# Altium schematic sheet DigitalLabBoard_Stepper.SchDoc(DigitalLabBoard_Stepper)
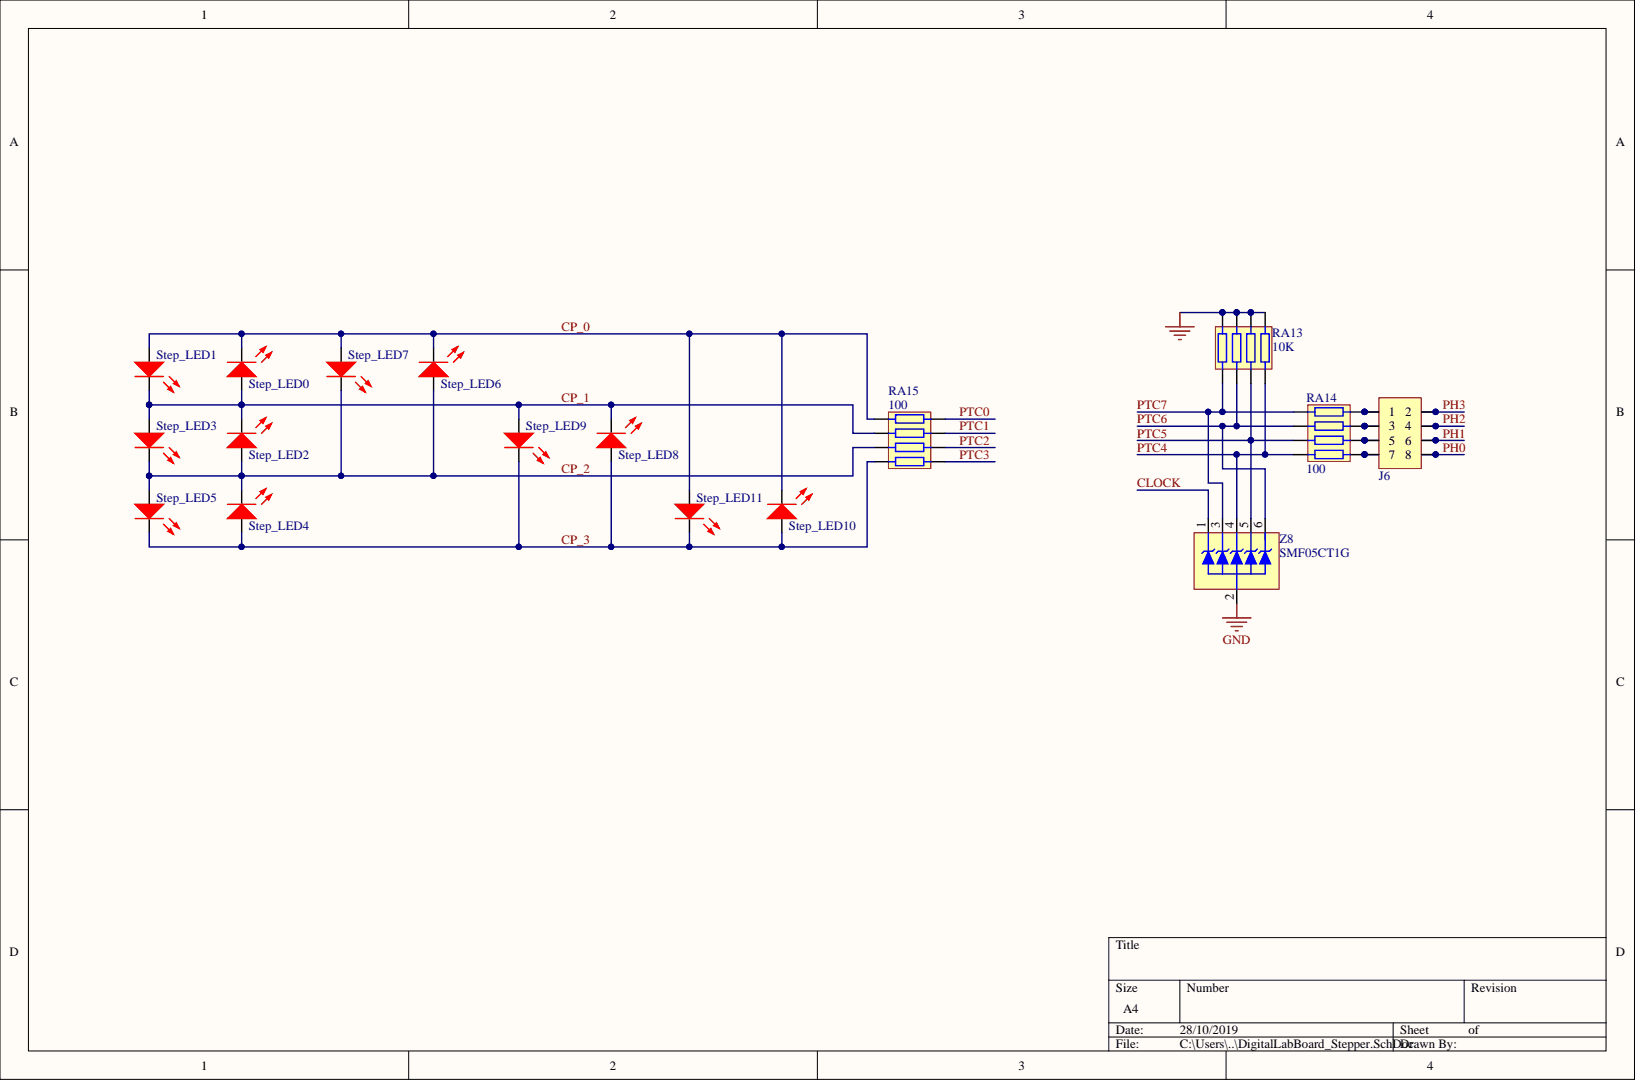
[diagram p0: DigitalLabBoard_Stepper.SchDoc]

## Parts
J6  2x5 Header  [HDR2X4S]  (value not drawn)
RA13  10K  [CR1206-0603_X4]
RA14  100  [CR1206-0603_X4]
RA15  100  [CR1206-0603_X4]
Step_LED0  LED Red  [LED1608-0603-RED]  (value not drawn)
Step_LED1  LED Red  [LED1608-0603-RED]  (value not drawn)
Step_LED2  LED Red  [LED1608-0603-RED]  (value not drawn)
Step_LED3  LED Red  [LED1608-0603-RED]  (value not drawn)
Step_LED4  LED Red  [LED1608-0603-RED]  (value not drawn)
Step_LED5  LED Red  [LED1608-0603-RED]  (value not drawn)
Step_LED6  LED Red  [LED1608-0603-RED]  (value not drawn)
Step_LED7  LED Red  [LED1608-0603-RED]  (value not drawn)
Step_LED8  LED Red  [LED1608-0603-RED]  (value not drawn)
Step_LED9  LED Red  [LED1608-0603-RED]  (value not drawn)
Step_LED10  LED Red  [LED1608-0603-RED]  (value not drawn)
Step_LED11  LED Red  [LED1608-0603-RED]  (value not drawn)
Z8  SMF05CT1G  [SC70_N]

## Nets
GND: RA13.1 RA13.2 RA13.3 RA13.4 Z8.2
CLOCK: Z8.1
CP_0: RA15.1 Step_LED0.K Step_LED1.A Step_LED6.K Step_LED7.A Step_LED10.K Step_LED11.A
CP_1: RA15.2 Step_LED0.A Step_LED1.K Step_LED2.K Step_LED3.A Step_LED8.K Step_LED9.A
CP_2: RA15.3 Step_LED2.A Step_LED3.K Step_LED4.K Step_LED5.A Step_LED6.A Step_LED7.K
CP_3: RA15.4 Step_LED4.A Step_LED5.K Step_LED8.A Step_LED9.K Step_LED10.A Step_LED11.K
PH0: J6.7 J6.8 RA14.4
PH1: J6.5 J6.6 RA14.3
PH2: J6.3 J6.4 RA14.2
PH3: J6.1 J6.2 RA14.1
PTC0: RA15.8
PTC1: RA15.7
PTC2: RA15.6
PTC3: RA15.5
PTC4: RA13.8 RA14.5 Z8.4
PTC5: RA13.7 RA14.6 Z8.5
PTC6: RA13.6 RA14.7 Z8.6
PTC7: RA13.5 RA14.8 Z8.3

## Library data of the parts
J6 = Header 2x4a: Header 2x4; Library Name: MyLibrary.IntLib; Component Kind: Standard
RA13-RA15 = Res_CR0603x4: SMT x4 Resistor Array; Library Name: MyLibrary.IntLib; Component Kind: Standard
  RA13: Value: 10K
  RA14 RA15: Value: 100
Step_LED0-Step_LED11 = LED-0603-Red: LED 0603 Red; Manufacturer: Generic Components; Class I: Optoelectronic; Revision: July-2002: Re-released for DXP Platform.; Published: 8-Jun-2000; Library Name: MyLibrary.IntLib; Farnell PN: 1581239; Component Kind: Standard; Class II: LED; Publisher: Altium Limited
Z8 = SMF05CT1G: TVS DIODE ARRAY, 100W, 5V, SC-70; Library Name: MySchLib.schlib; Farnell PN: 2533147; Component Kind: Standard
